# Altium schematic sheet Input.SchDoc
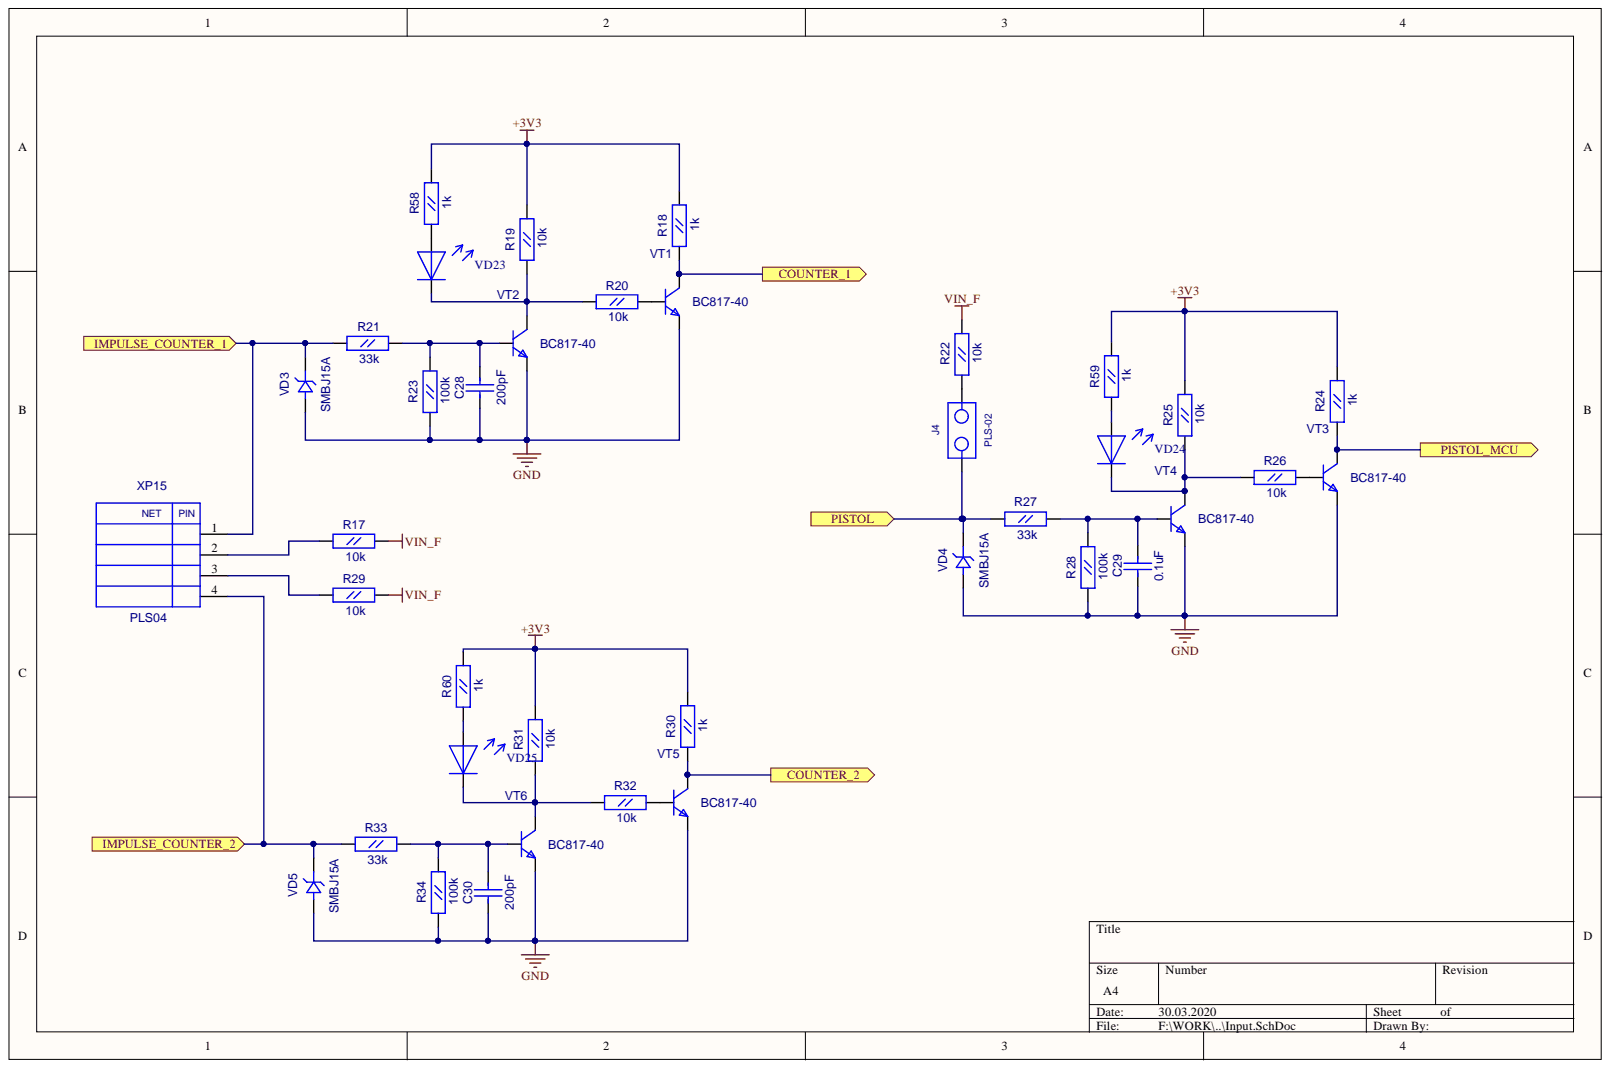
[diagram p0: Input.SchDoc]

## Parts
C28  200pF  [CC0603]
C29  0.1uF  [CC0603]
C30  200pF  [CC0603]
J4  PLS-02  [PLS-02]
R17  10k  [RC0603]
R18  1k  [RC0603]
R19  10k  [RC0603]
R20  10k  [RC0603]
R21  33k  [RC0603]
R22  10k  [RC0603]
R23  100k  [RC0603]
R24  1k  [RC0603]
R25  10k  [RC0603]
R26  10k  [RC0603]
R27  33k  [RC0603]
R28  100k  [RC0603]
R29  10k  [RC0603]
R30  1k  [RC0603]
R31  10k  [RC0603]
R32  10k  [RC0603]
R33  33k  [RC0603]
R34  100k  [RC0603]
R58  1k  [RC0603]
R59  1k  [RC0603]
R60  1k  [RC0603]
VD3  SMBJ15A  [SMB]
VD4  SMBJ15A  [SMB]
VD5  SMBJ15A  [SMB]
VD23  LED_0805  [LED0805]  (value not drawn)
VD24  LED_0805  [LED0805]  (value not drawn)
VD25  LED_0805  [LED0805]  (value not drawn)
VT1  BC817-40  [SOT-23(123)]
VT2  BC817-40  [SOT-23(123)]
VT3  BC817-40  [SOT-23(123)]
VT4  BC817-40  [SOT-23(123)]
VT5  BC817-40  [SOT-23(123)]
VT6  BC817-40  [SOT-23(123)]
XP15  PLS04  [PIN04S]

## Ports
COUNTER_1, COUNTER_2, IMPULSE_COUNTER_1, IMPULSE_COUNTER_2, PISTOL, PISTOL_MCU

## Nets
+3V3: R18.2 R19.2 R24.2 R25.2 R30.2 R31.2 R58.2 R59.2 R60.2
GND: C28.1 C29.1 C30.1 R23.1 R28.1 R34.1 VD3.1 VD4.1 VD5.1 VT1.2 VT2.2 VT3.2 VT4.2 VT5.2 VT6.2
VIN_F: R17.2 R22.2 R29.2
COUNTER_1: R18.1 VT1.3 ; port COUNTER_1
COUNTER_2: R30.1 VT5.3 ; port COUNTER_2
IMPULSE_COUNTER_1: R21.1 VD3.2 XP15.1 ; port IMPULSE_COUNTER_1
IMPULSE_COUNTER_2: R33.1 VD5.2 XP15.4 ; port IMPULSE_COUNTER_2
PISTOL: J4.1 R27.1 VD4.2 ; port PISTOL
PISTOL_MCU: R24.1 VT3.3 ; port PISTOL_MCU
NetC28_2: C28.2 R21.2 R23.2 VT2.1
NetC29_2: C29.2 R27.2 R28.2 VT4.1
NetC30_2: C30.2 R33.2 R34.2 VT6.1
NetJ4_2: J4.2 R22.1
NetR17_1: R17.1 XP15.2
NetR19_1: R19.1 R20.1 VD23.2 VT2.3
NetR20_2: R20.2 VT1.1
NetR25_1: R25.1 R26.1 VD24.2 VT4.3
NetR26_2: R26.2 VT3.1
NetR29_1: R29.1 XP15.3
NetR31_1: R31.1 R32.1 VD25.2 VT6.3
NetR32_2: R32.2 VT5.1
NetR58_1: R58.1 VD23.1
NetR59_1: R59.1 VD24.1
NetR60_1: R60.1 VD25.1

## Library data of the parts
C28-C30 = CC0603: Component Kind: Standard; Description: CC0603; Library Name: gprs_modem_2.SchLib
  C28 C30: Value: 200pF
  C29: Value: 0.1uF
R17-R34 R58-R60 = RC0603: Component Kind: Standard; Description: RC0603; Library Name: gprs_modem_2.SchLib
  R17 R19 R20 R22 R25 R26 R29 R31 R32: Value: 10k
  R18 R24 R30 R58-R60: Value: 1k
  R21 R27 R33: Value: 33k
  R23 R28 R34: Value: 100k
VD3-VD5 = SMBJ: Component Kind: Standard; Description: SMBJ; Library Name: gprs_modem_2.SchLib; Value: SMBJ15A
VT1-VT6 = BC846BLT1: NPN 500ma transistor; ComponentField7: Published 29-Sep-2000; Component Kind: Standard; Library Name: gprs_modem_2.SchLib; OriginalName: BC846BLT1; Simulation: BC846BLT1; Value: BC817-40
J4 = PLS-02: Component Kind: Standard; Description: PLS-02; Library Name: gprs_modem_2.SchLib
VD23-VD25 = LED_0805: Component Kind: Standard; Library Name: gprs_modem_2.SchLib; Value: LED_0805
XP15 = PLS04: Component Kind: Standard; Description: PLS04; Library Name: gprs_modem_2.SchLib
